# Altium schematic sheet ZLKJ-AKEMAchassis - V5 2020.9.21.SchDoc("ZLKJ-AKEMAchassis - V5 2020.9.21")
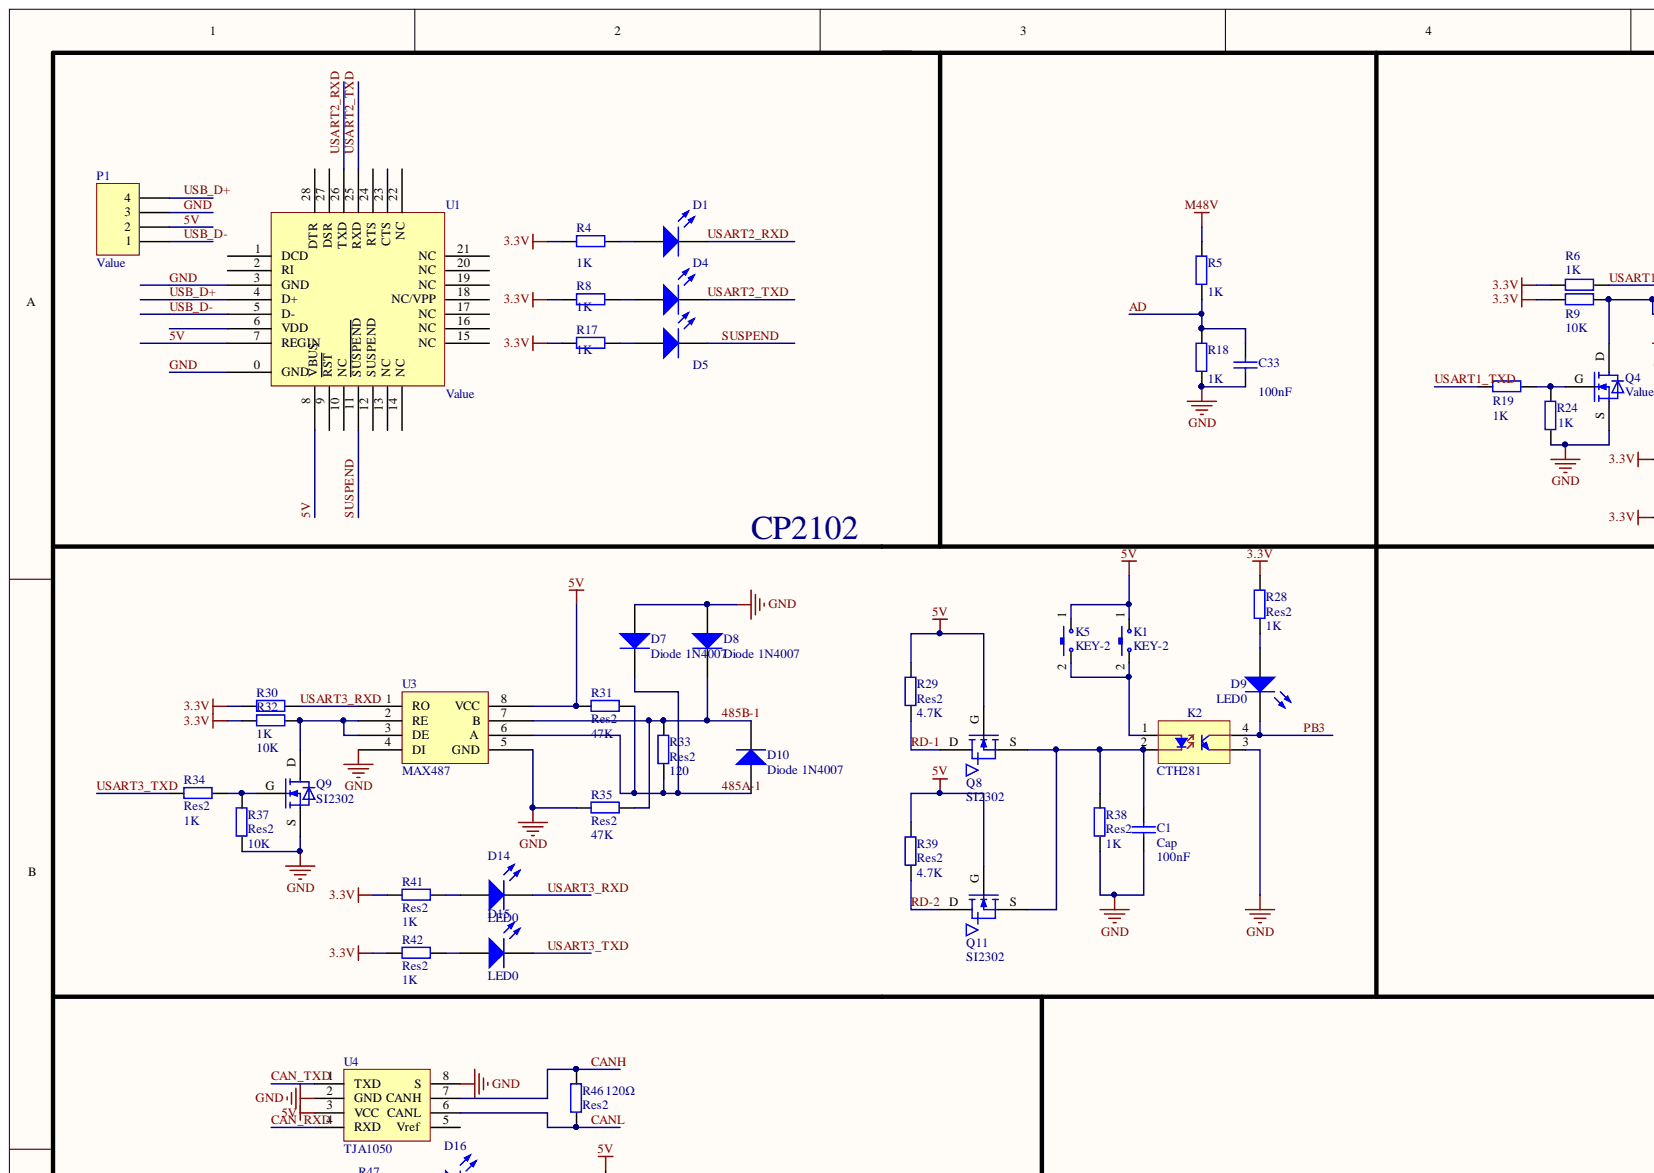
[diagram p0: ZLKJ-AKEMAchassis - V5 2020.9.21.SchDoc, top left]
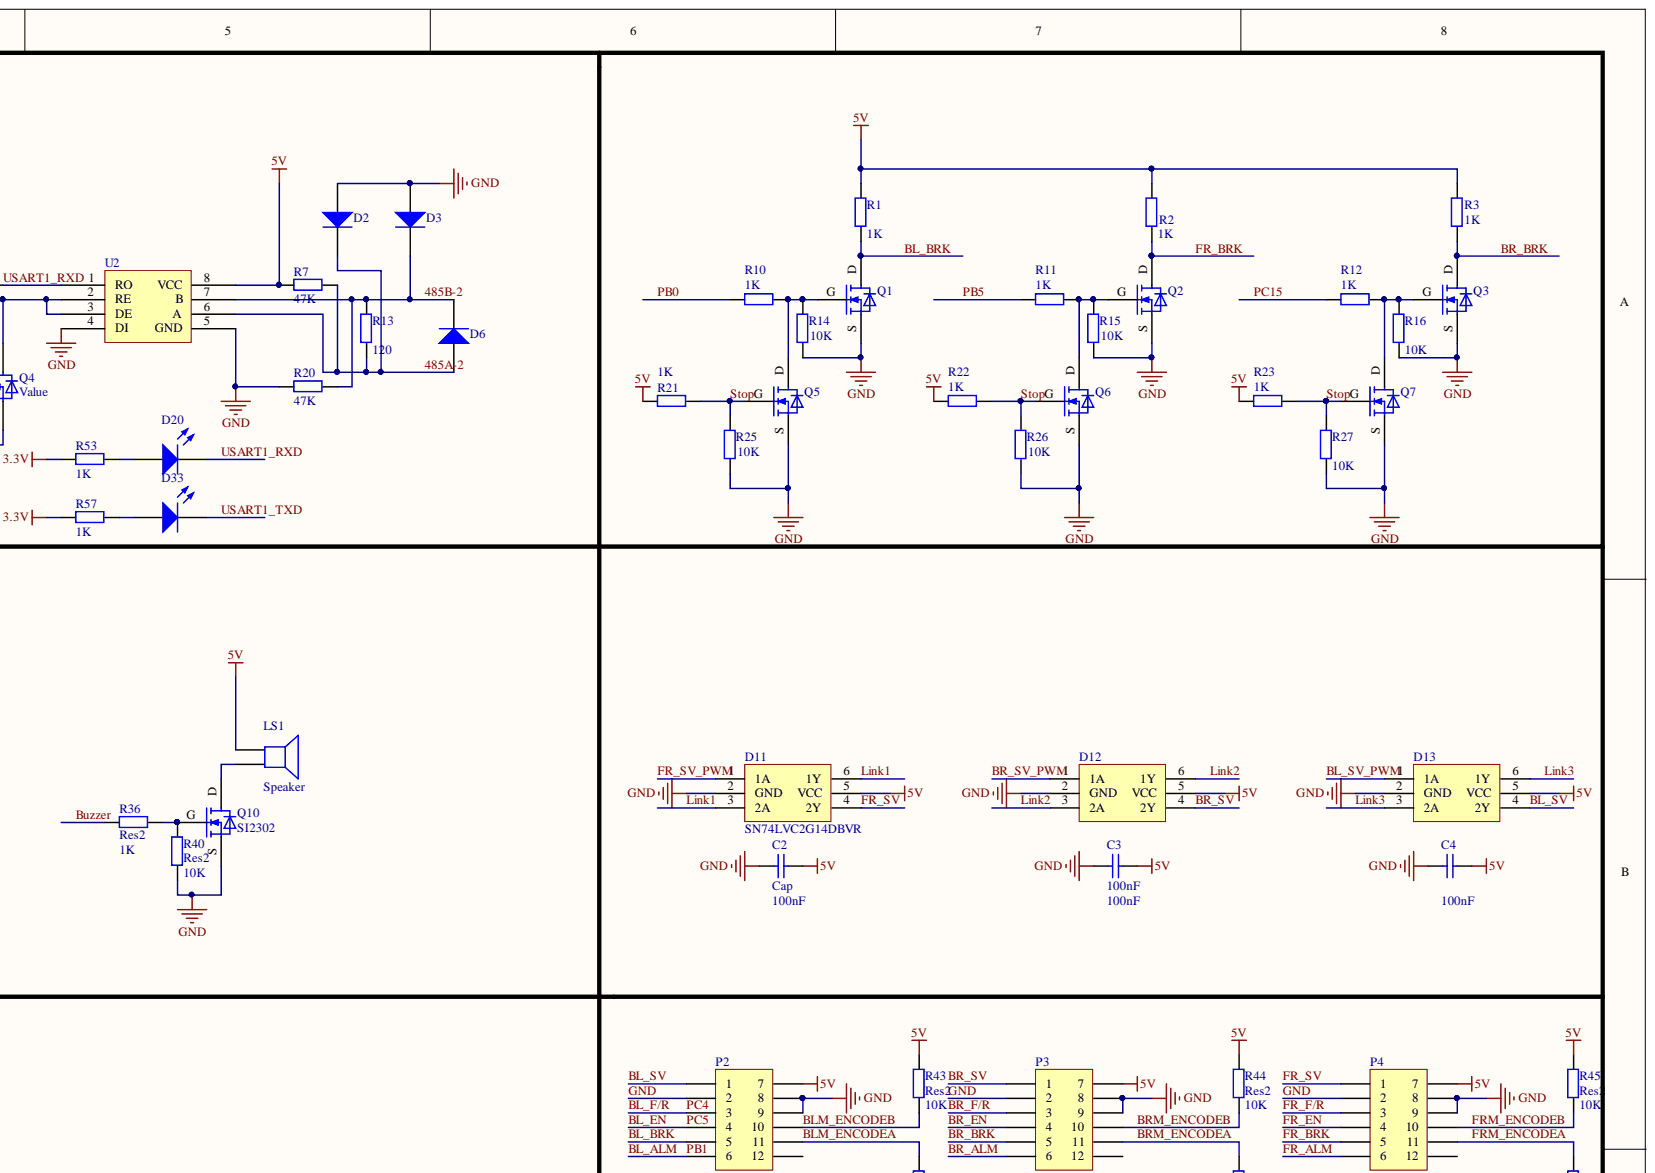
[diagram p1: ZLKJ-AKEMAchassis - V5 2020.9.21.SchDoc, top right]
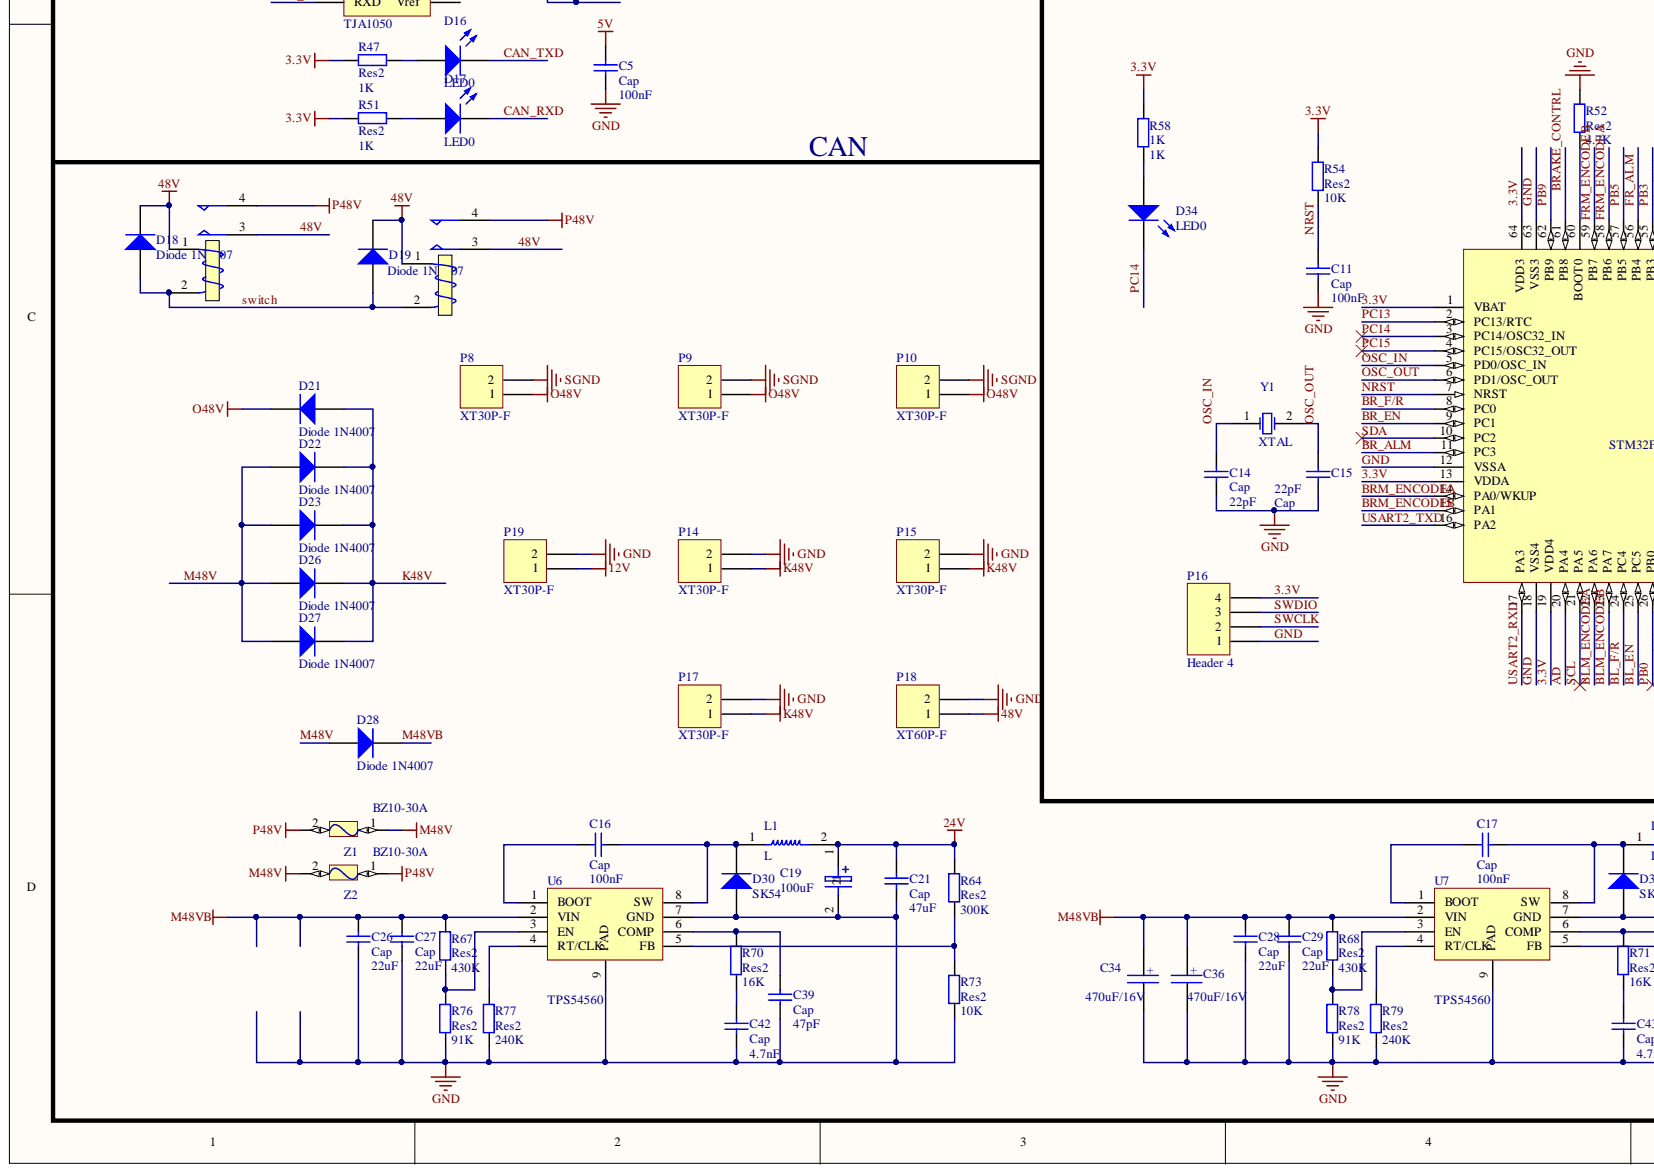
[diagram p2: ZLKJ-AKEMAchassis - V5 2020.9.21.SchDoc, bottom left]
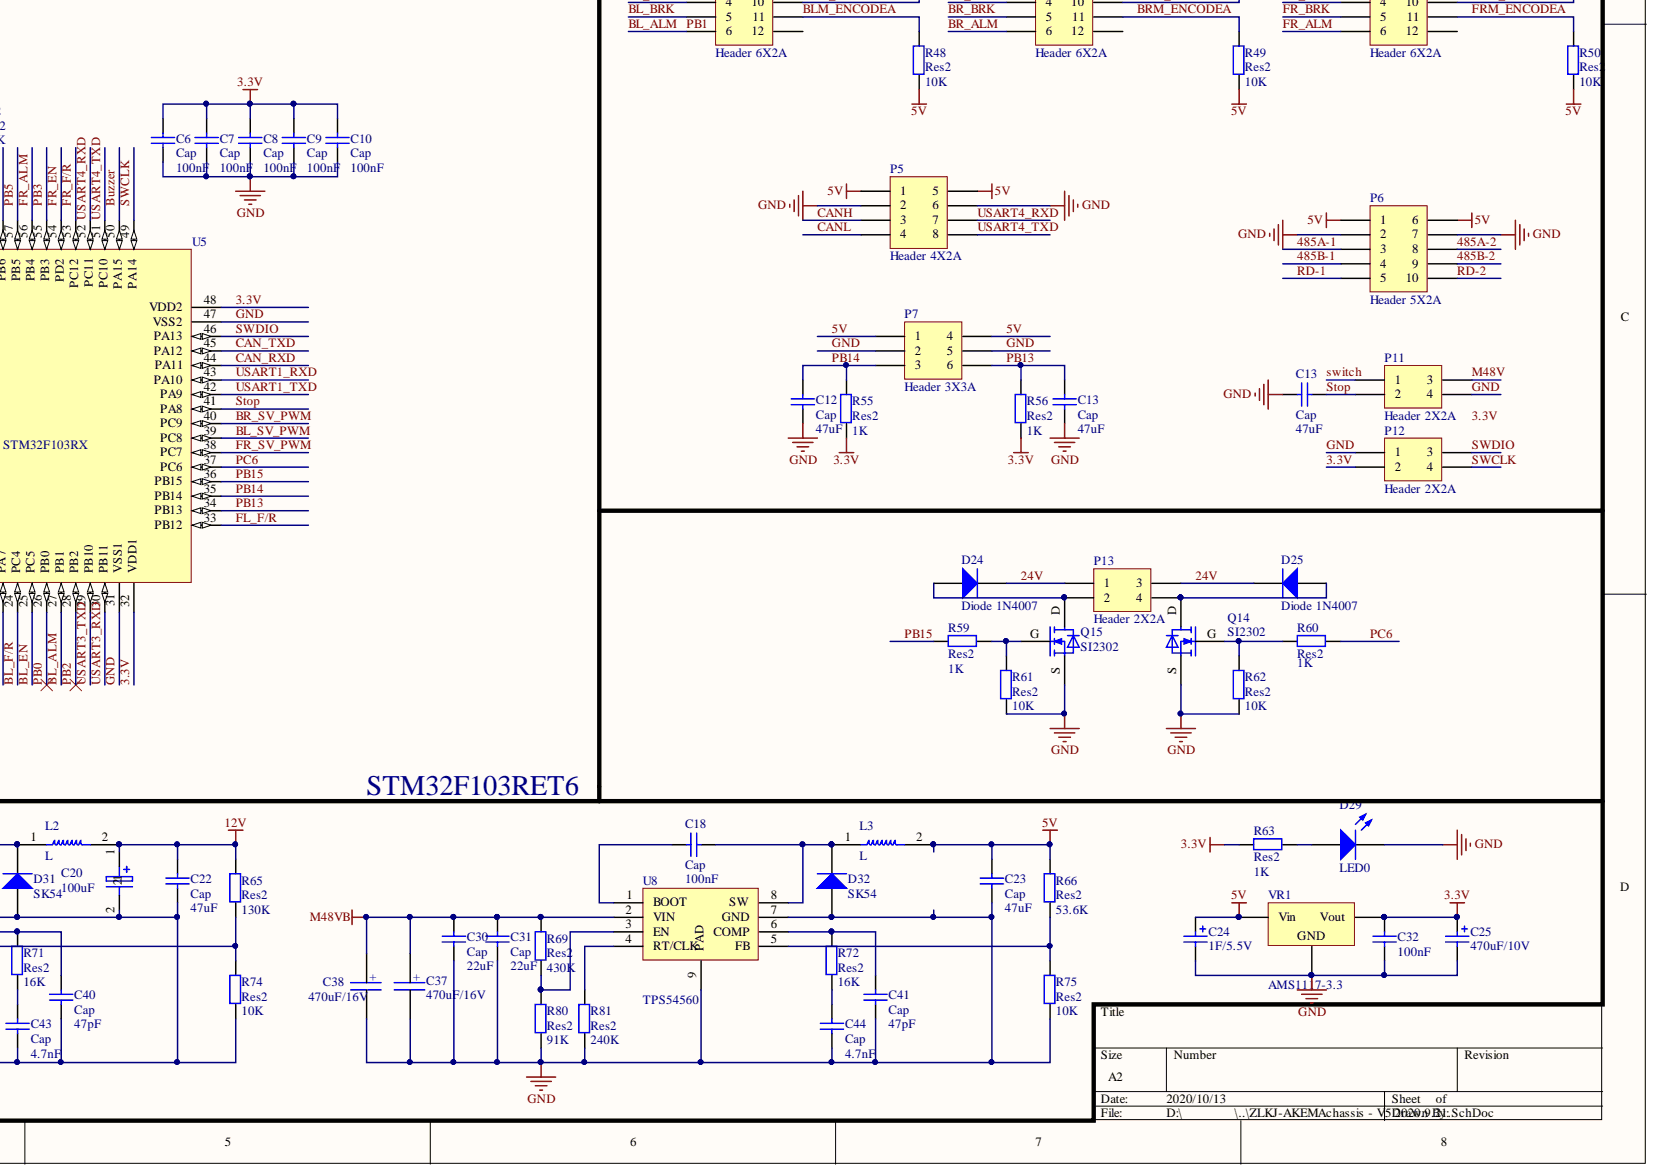
[diagram p3: ZLKJ-AKEMAchassis - V5 2020.9.21.SchDoc, bottom right]

## Parts
C1  Cap  value 100nF  [0603C]
C2  Cap  value 100nF  [0603C]
C3  100nF  [0603C]
C4  100nF  [0603C]
C5  Cap  value 100nF  [0603C]
C6  Cap  value 100nF  [0603C]
C7  Cap  value 100nF  [0603C]
C8  Cap  value 100nF  [0603C]
C9  Cap  value 100nF  [0603C]
C10  Cap  value 100nF  [0603C]
C11  Cap  value 100nF  [0603C]
C12  Cap  value 47uF  [0603C]
C13  Cap  value 47uF  [0603C]
C13  Cap  value 47uF  [0603C]
C14  Cap  value 22pF  [0603C]
C15  Cap  value 22pF  [0603C]
C16  Cap  value 100nF  [0603C]
C17  Cap  value 100nF  [0603C]
C18  Cap  value 100nF  [0603C]
C19  100uF  [电解电容10*10.2]
C20  100uF  [电解电容10*10.2]
C21  Cap  value 47uF  [C1206]
C22  Cap  value 47uF  [C1206]
C23  Cap  value 47uF  [C1206]
C24  1F/5.5V  [C-CHAOJI]
C25  470uF/10V  [电解电容10*10.2]
C26  Cap  value 22uF  [C1206]
C27  Cap  value 22uF  [C1206]
C28  Cap  value 22uF  [C1206]
C29  Cap  value 22uF  [C1206]
C30  Cap  value 22uF  [C1206]
C31  Cap  value 22uF  [C1206]
C32  100nF  [0603_C]
C33  100nF  [0603C]
C34  470uF/16V  [电解电容10*10.2]
C36  470uF/16V  [电解电容10*10.2]
C37  470uF/16V  [电解电容10*10.2]
C38  470uF/16V  [电解电容10*10.2]
C39  Cap  value 47pF  [0603C]
C40  Cap  value 47pF  [0603C]
C41  Cap  value 47pF  [0603C]
C42  Cap  value 4.7nF  [0603C]
C43  Cap  value 4.7nF  [0603C]
C44  Cap  value 4.7nF  [0603C]
D1  Value  [0603LED]  (value not drawn)
D2  Value  [D1206]  (value not drawn)
D3  Value  [D1206]  (value not drawn)
D4  Value  [0603LED]  (value not drawn)
D5  Value  [0603LED]  (value not drawn)
D6  Value  [D1206]  (value not drawn)
D7  Diode 1N4007  [D1206]
D8  Diode 1N4007  [D1206]
D9  LED0  [0603LED]
D10  Diode 1N4007  [D1206]
D11  SN74LVC2G14DBVR  [SOT-23-6]
D12  Value  [SOT-23-6]  (value not drawn)
D13  Value  [SOT-23-6]  (value not drawn)
D14  LED0  [0603LED]
D15  LED0  [0603LED]
D16  LED0  [0603LED]
D17  LED0  [0603LED]
D18  Diode 1N4007  [D1206]
D19  Diode 1N4007  [D1206]
D20  Value  [0603LED]  (value not drawn)
D21  Diode 1N4007  [S03]
D22  Diode 1N4007  [20A-1000]
D23  Diode 1N4007  [20A-1000]
D24  Diode 1N4007  [D1206]
D25  Diode 1N4007  [D1206]
D26  Diode 1N4007  [20A-1000]
D27  Diode 1N4007  [20A-1000]
D28  Diode 1N4007  [S03]
D29  LED0  [0603LED]
D30  SK54  [S03]
D31  SK54  [S03]
D32  SK54  [S03]
D33  Value  [0603LED]  (value not drawn)
D34  LED0  [0603LED]
K1  KEY-2  [KEY]
K2  CTH281  [CTH281]
K3  K1  [JD24V-4PIN]  (value not drawn)
K4  K1  [JD24V-4PIN]  (value not drawn)
K5  KEY-2  [轻触开关]
L1  L  [WHC1265-8.2UH]
L2  L  [WHC1265-8.2UH]
L3  L  [WHC1265-8.2UH]
LS1  Speaker  [0905-SP]
P1  Value  [USB(方口)]
P2  Header 6X2A  [15EDGRH3.81-6P]
P3  Header 6X2A  [15EDGRH3.81-6P]
P4  Header 6X2A  [15EDGRH3.81-6P]
P5  Header 4X2A  [15EDGRH3.81-4P]
P6  Header 5X2A  [15EDGRH3.81-5P]
P7  Header 3X3A  [15EDGRH3.81-3P]
P8  XT30P-F  [XT30P-F]
P9  XT30P-F  [XT30P-F]
P10  XT30P-F  [XT30P-F]
P11  Header 2X2A  [15EDGRH3.81-2P]
P12  Header 2X2A  [15EDGRH3.81-2P]
P13  Header 2X2A  [15EDGRH3.81-2P]
P14  XT30P-F  [XT30PW-F]
P15  XT30P-F  [XT30PW-F]
P16  Header 4  [HDR1X4]
P17  XT30P-F  [XT30PW-F]
P18  XT60P-F  [XT60PW-M20]
P19  XT30P-F  [XT30PW-F]
Q1  Value  [MOS-SOT-2302 - duplicate]  (value not drawn)
Q2  Value  [MOS-SOT-2302 - duplicate]  (value not drawn)
Q3  Value  [MOS-SOT-2302 - duplicate]  (value not drawn)
Q4  Value  [MOS-SOT-2302 - duplicate]
Q5  Value  [MOS-SOT-2302 - duplicate]  (value not drawn)
Q6  Value  [MOS-SOT-2302 - duplicate]  (value not drawn)
Q7  Value  [MOS-SOT-2302 - duplicate]  (value not drawn)
Q8  SI2302  [MOS-SOT-2302 - duplicate]
Q9  SI2302  [MOS-SOT-2302 - duplicate]
Q10  SI2302  [MOS-SOT-2302 - duplicate]
Q11  SI2302  [MOS-SOT-2302 - duplicate]
Q14  SI2302  [MOS-SOT-2302 - duplicate]
Q15  SI2302  [MOS-SOT-2302 - duplicate]
R1  1K  [0603R]
R2  1K  [0603R]
R3  1K  [0603R]
R4  1K  [0603R]
R5  1K  [0603R]
R6  1K  [0603R]
R7  47K  [0603R]
R8  1K  [0603R]
R9  10K  [0603R]
R10  1K  [0603R]
R11  1K  [0603R]
R12  1K  [0603R]
R13  120  [0603R]
R14  10K  [0603R]
R15  10K  [0603R]
R16  10K  [0603R]
R17  1K  [0603R]
R18  1K  [0603R]
R19  1K  [0603R]
R20  47K  [0603R]
R21  1K  [0603R]
R22  1K  [0603R]
R23  1K  [0603R]
R24  1K  [0603R]
R25  10K  [0603R]
R26  10K  [0603R]
R27  10K  [0603R]
R28  Res2  value 1K  [0603R]
R29  Res2  value 4.7K  [0603R]
R30  value 1K  [0603R]  (hidden comment: Res2)
R31  Res2  value 47K  [0603R]
R32  value 10K  [0603R]  (hidden comment: Res2)
R33  Res2  value 120  [0603R]
R34  Res2  value 1K  [0603R]
R35  Res2  value 47K  [0603R]
R36  Res2  value 1K  [0603R]
R37  Res2  value 10K  [0603R]
R38  Res2  value 1K  [0603R]
R39  Res2  value 4.7K  [0603R]
R40  Res2  value 10K  [0603R]
R41  Res2  value 1K  [0603R]
R42  Res2  value 1K  [0603R]
R43  Res2  value 10K  [0603R]
R44  Res2  value 10K  [0603R]
R45  Res2  value 10K  [0603R]
R46  Res2  value 120Ω  [0603R]
R47  Res2  value 1K  [0603R]
R48  Res2  value 10K  [0603R]
R49  Res2  value 10K  [0603R]
R50  Res2  value 10K  [0603R]
R51  Res2  value 1K  [0603R]
R52  Res2  value 4.7K  [0603R]
R53  1K  [0603R]
R54  Res2  value 10K  [0603R]
R55  Res2  value 1K  [0603R]
R56  Res2  value 1K  [0603R]
R57  1K  [0603R]
R58  1K  [0603R]
R59  Res2  value 1K  [0603R]
R60  Res2  value 1K  [0603R]
R61  Res2  value 10K  [0603R]
R62  Res2  value 10K  [0603R]
R63  Res2  value 1K  [0603R]
R64  Res2  value 300K  [0603R]
R65  Res2  value 130K  [0603R]
R66  Res2  value 53.6K  [0603R]
R67  Res2  value 430K  [0603R]
R68  Res2  value 430K  [0603R]
R69  Res2  value 430K  [0603R]
R70  Res2  value 16K  [0603R]
R71  Res2  value 16K  [0603R]
R72  Res2  value 16K  [0603R]
R73  Res2  value 10K  [0603R]
R74  Res2  value 10K  [0603R]
R75  Res2  value 10K  [0603R]
R76  Res2  value 91K  [0603R]
R77  Res2  value 240K  [0603R]
R78  Res2  value 91K  [0603R]
R79  Res2  value 240K  [0603R]
R80  Res2  value 91K  [0603R]
R81  Res2  value 240K  [0603R]
U1  Value  [CP2102]
U2  Value  [RS-485]
U3  MAX487  [RS-485]
U4  TJA1050  [TJA1050]
U5  STM32F103RX  [STM32F103RX]
U6  TPS54560  [TPS54560]
U7  TPS54560  [TPS54560]
U8  TPS54560  [TPS54560]
VR1  AMS1117-3.3  [SOT-223]
Y1  XTAL  [XATL]
Z1  BZ10-30A  [RP18-2]
Z2  BZ10-30A  [立式中号汽车保险座]

## Nets
12V: C20.1 C22.1 L2.2 P19.1 R65.1
O48V: D21.2 P8.1 P9.1 P10.1
P48V: K3.4 K4.4 Z1.2 Z2.1
SGND: P8.2 P9.2 P10.2
3.3V: C6.1 C7.1 C8.1 C9.1 C10.1 C25.1 C32.2 P12.2 P16.4 R4.2 R6.1 R8.2 R9.2 R17.2 R28.1 R30.1 R32.2 R41.2 R42.2 R47.2 R51.2 R53.2 R54.1 R55.1 R56.1 R57.2 R58.2 R63.2 U5.1(VBAT) U5.13(VDDA) U5.19(VDD4) U5.32(VDD1) U5.48(VDD2) U5.64(VDD3) VR1.2
5V: C2.2 C3.2 C4.2 C5.1 C23.1 C24.1 D11.5(VCC) D12.5(VCC) D13.5(VCC) K1.1 K5.1 L3.2 LS1.1 P1.2 P2.7 P3.7 P4.7 P5.1 P5.5 P6.1 P6.6 P7.1 P7.4 Q8.G Q11.G R1.2 R2.2 R3.2 R7.1 R21.1 R22.1 R23.1 R29.1 R31.1 R39.1 R43.2 R44.2 R45.2 R48.1 R49.1 R50.1 R66.1 U1.7(REGIN) U1.8(VBUS) U2.8(VCC) U3.8(VCC) U4.3(VCC) VR1.3
24V: C19.1 C21.1 D24.2 D25.2 L1.2 P13.1 P13.3 R64.1
48V: D18.2 D19.2 K3.1 K3.3 K4.1 K4.3 P18.1
485A-1: D7.2 D10.1 P6.3 R31.2 R33.2 U3.6(A)
485A-2: D2.2 D6.1 P6.8 R7.2 R13.2 U2.6(A)
485B-1: D8.2 D10.2 P6.4 R33.1 R35.2 U3.7(B)
485B-2: D3.2 D6.2 P6.9 R13.1 R20.1 U2.7(B)
AD: C33.1 R5.2 R18.1 U5.20(PA4)
BL_ALM: P2.6 U5.27(PB1)
BL_BRK: P2.5 Q1.D R1.1
BL_EN: P2.4 U5.25(PC5)
BL_F/R: P2.3 U5.24(PC4)
BL_SV: D13.4(2Y) P2.1
BL_SV_PWM: D13.1(1A) U5.39(PC8)
BLM_ENCODEA: P2.11 R48.2 U5.22(PA6)
BLM_ENCODEB: P2.10 R43.1 U5.23(PA7)
BR_ALM: P3.6 U5.11(PC3)
BR_BRK: P3.5 Q3.D R3.1
BR_EN: P3.4 U5.9(PC1)
BR_F/R: P3.3 U5.8(PC0)
BR_SV: D12.4(2Y) P3.1
BR_SV_PWM: D12.1(1A) U5.40(PC9)
BRAKE_CONTRL: U5.61(PB8)
BRM_ENCODEA: P3.11 R49.2 U5.14(PA0/WKUP)
BRM_ENCODEB: P3.10 R44.1 U5.15(PA1)
Buzzer: R36.2 U5.50(PA15)
CAN_RXD: D17.2 U4.4(RXD) U5.44(PA11)
CAN_TXD: D16.2 U4.1(TXD) U5.45(PA12)
CANH: P5.3 R46.2 U4.7(CANH)
CANL: P5.4 R46.1 U4.6(CANL)
FL_F/R: U5.33(PB12)
FR_ALM: P4.6 U5.56(PB4)
FR_BRK: P4.5 Q2.D R2.1
FR_EN: P4.4 U5.54(PD2)
FR_F/R: P4.3 U5.53(PC12)
FR_SV: D11.4(2Y) P4.1
FR_SV_PWM: D11.1(1A) U5.38(PC7)
FRM_ENCODEA: P4.11 R50.2 U5.58(PB6)
FRM_ENCODEB: P4.10 R45.1 U5.59(PB7)
GND: C1.2 C2.1 C3.1 C4.1 C5.2 C6.2 C7.2 C8.2 C9.2 C10.2 C11.2 C12.2 C13.2 C14.2 C15.2 C19.2 C20.2 C21.2 C22.2 C23.2 C24.2 C25.2 C26.2 C27.2 C28.2 C29.2 C30.2 C31.2 C32.1 C33.2 C34.2 C36.2 C37.2 C38.2 C39.2 C40.2 C41.2 C42.2 C43.2 C44.2 D2.1 D3.1 D7.1 D8.1 D11.2(GND) D12.2(GND) D13.2(GND) D29.2 D30.1 D31.1 D32.1 K2.3 P1.3 P2.2 P2.8 P2.9 P3.2 P3.8 P3.9 P4.2 P4.8 P4.9 P5.2 P5.6 P6.2 P6.7 P7.2 P7.5 P11.4 P12.1 P14.2 P15.2 P16.1 P17.2 P18.2 P19.2 Q1.S Q2.S Q3.S Q4.S Q5.S Q6.S Q7.S Q9.S Q10.S Q14.S Q15.S R14.1 R15.1 R16.1 R18.2 R20.2 R24.2 R25.1 R26.1 R27.1 R35.1 R37.2 R38.2 R40.2 R52.2 R61.2 R62.2 R73.2 R74.2 R75.2 R76.2 R77.2 R78.2 R79.2 R80.2 R81.2 U1.0(GND) U1.3(GND) U2.4(DI) U2.5(GND) U3.4(DI) U3.5(GND) U4.2(GND) U4.8(S) U5.12(VSSA) U5.18(VSS4) U5.31(VSS1) U5.47(VSS2) U5.63(VSS3) U6.7(GND) U6.9(PAD) U7.7(GND) U7.9(PAD) U8.7(GND) U8.9(PAD) VR1.1
K48V: D21.1 D22.2 D23.2 D26.2 D27.2 P14.1 P15.1 P17.1
Link1: D11.3(2A) D11.6(1Y)
Link2: D12.3(2A) D12.6(1Y)
Link3: D13.3(2A) D13.6(1Y)
M48V: D22.1 D23.1 D26.1 D27.1 D28.1 P11.3 R5.1 Z1.1 Z2.2
M48VB: C26.1 C27.1 C28.1 C29.1 C30.1 C31.1 C34.1 C36.1 C37.1 C38.1 D28.2 R67.1 R68.1 R69.1 U6.2(VIN) U7.2(VIN) U8.2(VIN)
NRST: C11.1 R54.2 U5.7(NRST)
OSC_IN: C14.1 U5.5(PD0/OSC_IN) Y1.1
OSC_OUT: C15.1 U5.6(PD1/OSC_OUT) Y1.2
PB0: R10.1 U5.26(PB0)
PB2: U5.28(PB2)
PB3: D9.2 K2.4 U5.55(PB3)
PB5: R11.1 U5.57(PB5)
PB9: U5.62(PB9)
PB13: C13.1 P7.6 R56.2 U5.34(PB13)
PB14: C12.1 P7.3 R55.2 U5.35(PB14)
PB15: R59.2 U5.36(PB15)
PC6: R60.1 U5.37(PC6)
PC13: U5.2(PC13/RTC)
PC14: D34.2 U5.3(PC14/OSC32_IN)
PC15: R12.1 U5.4(PC15/OSC32_OUT)
RD-1: P6.5 Q8.D R29.2
RD-2: P6.10 Q11.D R39.2
SCL: U5.21(PA5)
SDA: U5.10(PC2)
Stop: C13.1 P11.2 Q5.G Q6.G Q7.G R21.2 R22.2 R23.2 R25.2 R26.2 R27.2 U5.41(PA8)
SUSPEND: D5.2 U1.11(SUSPEND)
SWCLK: P12.4 P16.2 U5.49(PA14)
SWDIO: P12.3 P16.3 U5.46(PA13)
switch: D18.1 D19.1 K3.2 K4.2 P11.1
USART1_RXD: D20.2 R6.2 U2.1(RO) U5.43(PA10)
USART1_TXD: D33.2 R19.2 U5.42(PA9)
USART2_RXD: D1.2 U1.26(TXD) U5.17(PA3)
USART2_TXD: D4.2 U1.25(RXD) U5.16(PA2)
USART3_RXD: D14.2 R30.2 U3.1(RO) U5.30(PB11)
USART3_TXD: D15.2 R34.2 U5.29(PB10)
USART4_RXD: P5.7 U5.52(PC11)
USART4_TXD: P5.8 U5.51(PC10)
USB_D+: P1.4 U1.4(D+)
USB_D-: P1.1 U1.5(D-)
NetC1_1: C1.1 K2.2 Q8.S Q11.S R38.1
NetC16_1: C16.1 U6.1(BOOT)
NetC16_2: C16.2 D30.2 L1.1 U6.8(SW)
NetC17_1: C17.1 U7.1(BOOT)
NetC17_2: C17.2 D31.2 L2.1 U7.8(SW)
NetC18_1: C18.1 U8.1(BOOT)
NetC18_2: C18.2 D32.2 L3.1 U8.8(SW)
NetC39_1: C39.1 R70.1 U6.6(COMP)
NetC40_1: C40.1 R71.1 U7.6(COMP)
NetC41_1: C41.1 R72.1 U8.6(COMP)
NetC42_1: C42.1 R70.2
NetC43_1: C43.1 R71.2
NetC44_1: C44.1 R72.2
NetD1_1: D1.1 R4.1
NetD4_1: D4.1 R8.1
NetD5_1: D5.1 R17.1
NetD9_1: D9.1 R28.2
NetD14_1: D14.1 R41.1
NetD15_1: D15.1 R42.1
NetD16_1: D16.1 R47.1
NetD17_1: D17.1 R51.1
NetD20_1: D20.1 R53.1
NetD24_1: D24.1 P13.2 Q15.D
NetD25_1: D25.1 P13.4 Q14.D
NetD29_1: D29.1 R63.1
NetD33_1: D33.1 R57.1
NetD34_1: D34.1 R58.1
NetK1_2: K1.2 K2.1 K5.2
NetLS1_2: LS1.2 Q10.D
NetQ1_G: Q1.G Q5.D R10.2 R14.2
NetQ2_G: Q2.G Q6.D R11.2 R15.2
NetQ3_G: Q3.G Q7.D R12.2 R16.2
NetQ4_D: Q4.D R9.1 U2.2(RE) U2.3(DE)
NetQ4_G: Q4.G R19.1 R24.1
NetQ9_D: Q9.D R32.1 U3.2(RE) U3.3(DE)
NetQ9_G: Q9.G R34.1 R37.1
NetQ10_G: Q10.G R36.1 R40.1
NetQ14_G: Q14.G R60.2 R62.1
NetQ15_G: Q15.G R59.1 R61.1
NetR52_1: R52.1 U5.60(BOOT0)
NetR64_2: R64.2 R73.1 U6.5(FB)
NetR65_2: R65.2 R74.1 U7.5(FB)
NetR66_2: R66.2 R75.1 U8.5(FB)
NetR67_2: R67.2 R76.1 U6.3(EN)
NetR68_2: R68.2 R78.1 U7.3(EN)
NetR69_2: R69.2 R80.1 U8.3(EN)
NetR77_1: R77.1 U6.4(RT/CLK)
NetR79_1: R79.1 U7.4(RT/CLK)
NetR81_1: R81.1 U8.4(RT/CLK)

Not connected: P2 12; P3 12; P4 12; U1 1(DCD) 2(RI) 6(VDD) 9(RST) 10(NC) 12(SUSPEND) 13(NC) 14(NC) 15(NC) 16(NC) 17(NC) 18(NC/VPP) 19(NC) 20(NC) 21(NC) 22(NC) 23(CTS) 24(RTS) 27(DSR) 28(DTR); U4 5(Vref)

## Library data of the parts
C1-C13 C13-C15 C21-C23 C26-C31 C33 C39-C44 = Cap: Capacitor; Simulation: CAP; Signal Integrity: Cap; Published: 8-Jun-2000; PackageReference: RAD-0.3; Library Name: Miscellaneous Devices.IntLib; LatestRevisionNote: Re-released for DXP Platform.; LatestRevisionDate: 17-Jul-2002; Component Kind: Standard; Publisher: Altium Limited
  C3 C4 C33: Value: 100nF
C16-C18 = Cap: Capacitor; Value: 100nF; Simulation: CAP; Signal Integrity: Cap; Published: 8-Jun-2000; PackageReference: RAD-0.3; Library Name: Miscellaneous Devices.IntLib; LatestRevisionNote: Re-released for DXP Platform.; LatestRevisionDate: 17-Jul-2002; Component Kind: Standard; Publisher: Altium Limited
C19 C20 = CD1: Description: CD1; Component Kind: Standard
C24 C25 = 3528 100uF (107) 10% 6.3V: 钽电容; SuppliersPartNumber: C16133; Supplier: LC; Package: 3528; Library Name: Miscellaneous Devices LC.IntLib; ComponentLink1URL: http://www.szlcsc.com/product/details_16815.html; ComponentLink1Description: 供应商链接; Component Kind: Standard
C32 = 0603 100nF (104) 10% 50V: 贴片电容; SuppliersPartNumber: C14663; Supplier: LC; Package: 0603; Library Name: Miscellaneous Devices LC.IntLib; ComponentLink1URL: http://www.szlcsc.com/product/details_15331.html; ComponentLink1Description: 供应商链接; Component Kind: Standard
C34 C36-C38 = Cap: Library Name: MySchlib.SchLib; Component Kind: Standard
D1 D4 D5 D9 D14-D17 D20 D29 D33 D34 = LED0: Typical INFRARED GaAs LED; Simulation: LED0; Published: 8-Jun-2000; PackageReference: LED-0; Library Name: Miscellaneous Devices.IntLib; LatestRevisionNote: Mech Layer 1 removed.; LatestRevisionDate: 08-Jul-2005; Component Kind: Standard; Publisher: Altium Limited
D2 D3 D6-D8 D10 D18 D19 D21-D28 D30-D32 = Diode 1N4007: 1 Amp General Purpose Rectifier; Published: 8-Jun-2000; PackageVersion: Aug-1999; PackageReference: DO-41; Library Name: Miscellaneous Devices.IntLib; LatestRevisionNote: Re-released for DXP Platform.; LatestRevisionDate: 17-Jul-2002; DatasheetVersion: 1998; ComponentLink2URL: http://www.fairchildsemi.com/ds/1N%2F1N4001.pdf; ComponentLink2Description: Datasheet; ComponentLink1URL: http://www.fairchildsemi.com/; ComponentLink1Description: Manufacturer Link; Component Kind: Standard; Code_JEDEC: DO-204-AL; Publisher: Altium Limited
D12 D13 = SN74LVC2G14DBVR: Library Name: 原理图库1.SCHLIB; Component Kind: Standard
LS1 = Speaker: Loudspeaker; Published: 24-Mar-1999; PackageReference: PIN2; Library Name: Miscellaneous Devices.IntLib; LatestRevisionNote: Re-released for DXP Platform.; LatestRevisionDate: 17-Jul-2002; Component Kind: Standard; Publisher: Altium Limited
P1 P16 = Header 4: Header, 4-Pin; Signal Integrity: Connector; LatestRevisionNote: Re-released for DXP Platform.; LatestRevisionDate: 17-Jul-2002; Component Kind: Standard; Publisher: Altium Limited
  P1: Library Name: 原理图库1.SCHLIB
  P16: Library Name: Miscellaneous Connectors.IntLib
P2-P4 = Header 6X2A: Header, 6-Pin, Dual row; Signal Integrity: Connector; Library Name: Miscellaneous Connectors.IntLib; LatestRevisionNote: Re-released for DXP Platform.; LatestRevisionDate: 17-Jul-2002; Component Kind: Standard; Publisher: Altium Limited
P5 = Header 4X2A: Header, 4-Pin, Dual row; Signal Integrity: Connector; Library Name: Miscellaneous Connectors.IntLib; LatestRevisionNote: Re-released for DXP Platform.; LatestRevisionDate: 17-Jul-2002; Component Kind: Standard; Publisher: Altium Limited
P8-P10 P14 P15 P17-P19 = Header 2: Header, 2-Pin; Signal Integrity: Connector; Library Name: Miscellaneous Connectors.IntLib; LatestRevisionNote: Re-released for DXP Platform.; LatestRevisionDate: 17-Jul-2002; Component Kind: Standard; Publisher: Altium Limited
Q1-Q11 Q14 Q15 = MOS_N: Library Name: MySchlib.SchLib; Component Kind: Standard
R1-R29 R31 R33-R40 R42-R46 R48-R81 = Res2: Resistor; Simulation: RESISTOR; Signal Integrity: Res; Published: 8-Jun-2000; PackageReference: AXIAL-0.4; Library Name: Miscellaneous Devices.IntLib; LatestRevisionNote: Re-released for DXP Platform.; LatestRevisionDate: 17-Jul-2002; Component Kind: Standard; Publisher: Altium Limited
  R1-R6 R8 R10-R12 R17-R19 R21-R24 R53 R57 R58: Value: 1K
  R7 R20: Value: 47K
  R9 R14-R16 R25-R27: Value: 10K
  R13: Value: 120
R30 R41 R47 = Res2: Resistor; Value: 1K; Simulation: RESISTOR; Signal Integrity: Res; Published: 8-Jun-2000; PackageReference: AXIAL-0.4; Library Name: Miscellaneous Devices.IntLib; LatestRevisionNote: Re-released for DXP Platform.; LatestRevisionDate: 17-Jul-2002; Component Kind: Standard; Publisher: Altium Limited
R32 = Res2: Resistor; Value: 10K; Simulation: RESISTOR; Signal Integrity: Res; Published: 8-Jun-2000; PackageReference: AXIAL-0.4; Library Name: Miscellaneous Devices.IntLib; LatestRevisionNote: Re-released for DXP Platform.; LatestRevisionDate: 17-Jul-2002; Component Kind: Standard; Publisher: Altium Limited
U1 = CP2102: Component Kind: Standard
U2 = MAX487: Library Name: Schlib1.SchLib; Component Kind: Standard
VR1 = SOT-223 AMS1117-3.3: 低压差线性稳压(LDO); SuppliersPartNumber: C6186; Supplier: LC; Package: SOT-223; Library Name: Miscellaneous Devices LC.IntLib; ComponentLink1URL: http://www.szlcsc.com/product/details_6652.html; ComponentLink1Description: 供应商链接; Component Kind: Standard
Y1 = XTAL: Crystal Oscillator; Simulation: XTAL; Published: 8-Jun-2000; PackageReference: R38; Library Name: Miscellaneous Devices.IntLib; LatestRevisionNote: Re-released for DXP Platform.; LatestRevisionDate: 17-Jul-2002; Component Kind: Standard; Publisher: Altium Limited
D11 = SN74LVC2G14DBVR: Library Name: 原理图库1.SCHLIB; Component Kind: Standard
K1 K5 = KEY-2: Library Name: 原理图库1.SCHLIB; Component Kind: Standard
K2 = CTH281: Library Name: 原理图库1.SCHLIB; Component Kind: Standard
K3 K4 = K1: Component Kind: Standard; Library Name: Auto_Charge_IR_V1.00-A.SCHLIB
L1-L3 = L: Library Name: MySchlib.SchLib; Component Kind: Standard
P6 = Header 5X2A: Library Name: 原理图库1.SCHLIB; Component Kind: Standard
P7 = Header 3X3A: Library Name: 原理图库1.SCHLIB; Component Kind: Standard
P11-P13 = Header 2X2A: Library Name: 原理图库1.SCHLIB; Component Kind: Standard
U3 = MAX487: Library Name: Schlib1.SchLib; Component Kind: Standard
U4 = TJA1050: Library Name: MySchlib.SchLib; Component Kind: Standard
U5 = STM32F103RX: Library Name: MySchlib.SchLib; Component Kind: Standard
U6-U8 = TPS54560: Library Name: MySchlib.SchLib; Component Kind: Standard
Z1 Z2 = BZ10-30A: Library Name: MySchlib.SchLib; Component Kind: Standard
